# Altium schematic sheet Hopper.SchDoc(Hopper)
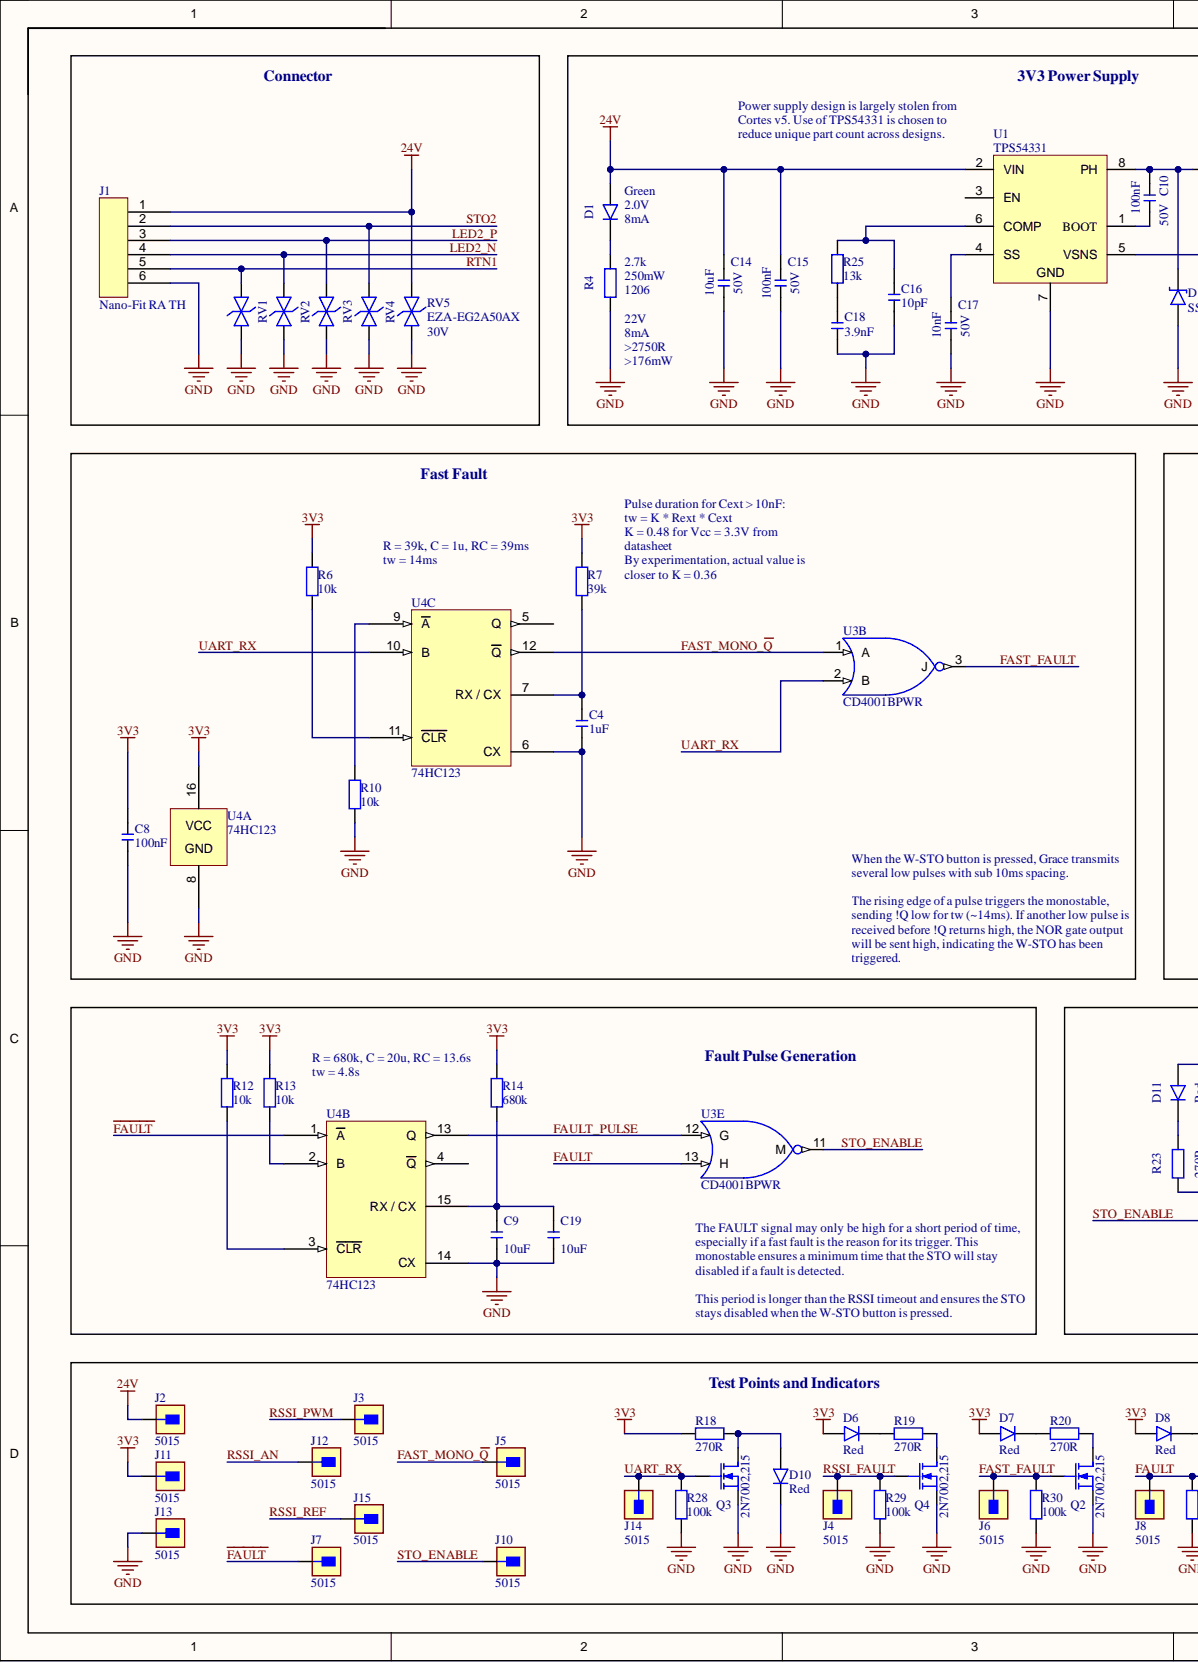
[diagram p0: Hopper.SchDoc, left]
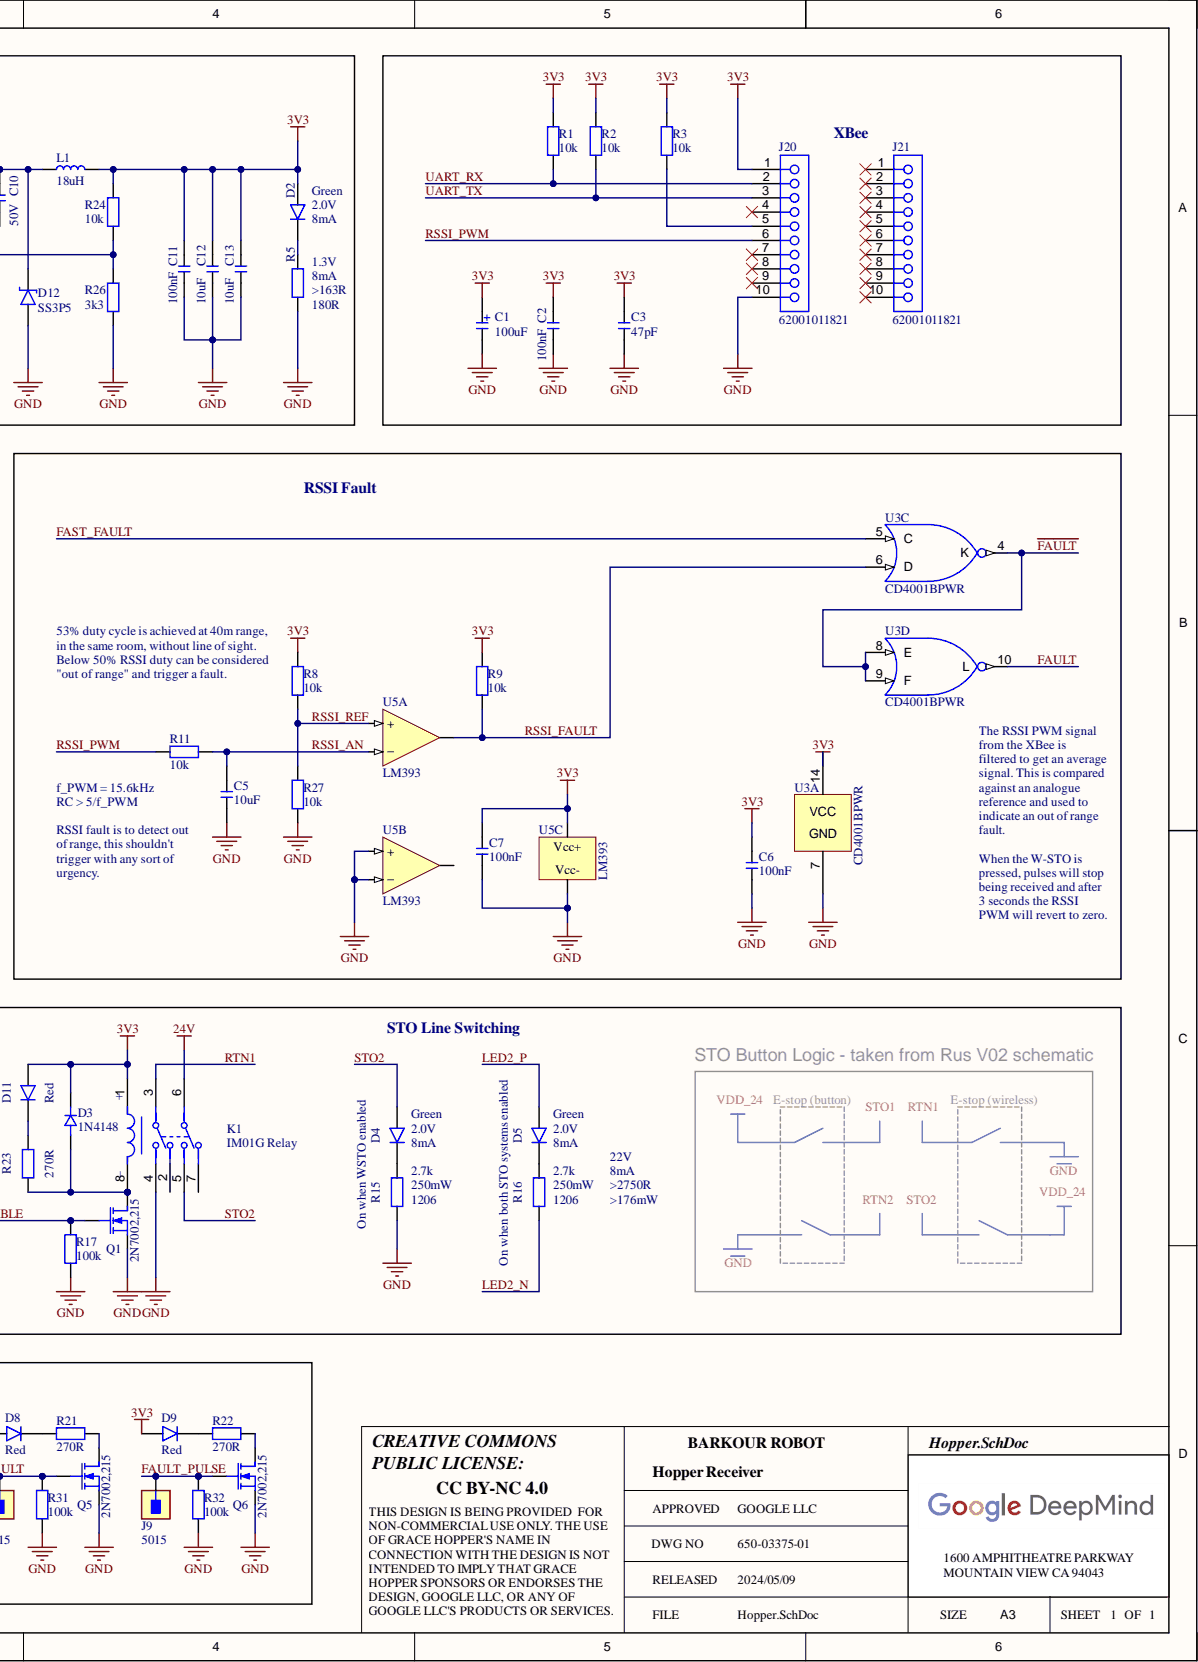
[diagram p1: Hopper.SchDoc, right]

## Parts
C1  100uF  [CAP ALSMD ELEC 5.3MM H5.8MM]
C2  100nF  [CAP 0402_1005 RF]
C3  47pF  [CAP 0603_1608 RF]
C4  1uF  [CAP 0603_1608 RF]
C5  10uF  [CAP 0603_1608 RF]
C6  100nF  [CAP 0603_1608 RF]
C7  100nF  [CAP 0603_1608 RF]
C8  100nF  [CAP 0603_1608 RF]
C9  10uF  [CAP 0402_1005]
C10  100nF  Voltage Rating: 50V  [CAP 0402_1005 RF]
C11  100nF  [CAP 0402_1005 RF]
C12  10uF  [CAP 0402_1005 RF]
C13  10uF  [CAP 0402_1005 RF]
C14  10uF  Voltage Rating: 50V  [CAP 1206_3216]
C15  100nF  Voltage Rating: 50V  [CAP 0402_1005 RF]
C16  10pF  [CAP 0402_1005 RF]
C17  10nF  Voltage Rating: 50V  [CAP 0402_1005 RF]
C18  3.9nF  [CAP 0402_1005 RF]
C19  10uF  [CAP 0402_1005]
D1  Colour: Green  Forward Voltage: 2.0V  [LED 0402_1005 GREEN]  (hidden comment: 150040VS73240)
D2  Colour: Green  Forward Voltage: 2.0V  [LED 0402_1005 GREEN]  (hidden comment: 150040VS73240)
D3  1N4148  [SOD323]
D4  Colour: Green  Forward Voltage: 2.0V  [LED 0402_1005 GREEN]  (hidden comment: 150040VS73240)
D5  Colour: Green  Forward Voltage: 2.0V  [LED 0402_1005 GREEN]  (hidden comment: 150040VS73240)
D6  Colour: Red  [LED 0402_1005 RED]  (hidden comment: 150040RS73240)
D7  Colour: Red  [LED 0402_1005 RED]  (hidden comment: 150040RS73240)
D8  Colour: Red  [LED 0402_1005 RED]  (hidden comment: 150040RS73240)
D9  Colour: Red  [LED 0402_1005 RED]  (hidden comment: 150040RS73240)
D10  Colour: Red  [LED 0402_1005 RED]  (hidden comment: 150040RS73240)
D11  Colour: Red  [LED 0402_1005 RED]  (hidden comment: 150040RS73240)
D12  Colloquial: SS3P5  [DO-220AA]  (hidden comment: SS3P5-M3/84A)
J1  Colloquial: Nano-Fit RA TH  [MOLEX 1053141206]  (hidden comment: 105314-1206)
J2  5015  [FP-5015-MFG-RF]
J3  5015  [FP-5015-MFG-RF]
J4  5015  [FP-5015-MFG-RF]
J5  5015  [FP-5015-MFG-RF]
J6  5015  [FP-5015-MFG-RF]
J7  5015  [FP-5015-MFG-RF]
J8  5015  [FP-5015-MFG-RF]
J9  5015  [FP-5015-MFG-RF]
J10  5015  [FP-5015-MFG-RF]
J11  5015  [FP-5015-MFG-RF]
J12  5015  [FP-5015-MFG-RF]
J13  5015  [FP-5015-MFG-RF]
J14  5015  [FP-5015-MFG-RF]
J15  5015  [FP-5015-MFG-RF]
J20  62001011821  [62001011821]
J21  62001011821  [62001011821]
K1  1462037-1  [TE IM Relay Gullwing]  (value not drawn)
L1  Inductance: 18uH  [INDUCTOR SMD SHIELDED 10x10mm]  (hidden comment: SRR1050A-180Y)
Q1  2N7002,215  [NEXPERIA SOT-23-3]
Q2  2N7002,215  [NEXPERIA SOT-23-3]
Q3  2N7002,215  [NEXPERIA SOT-23-3]
Q4  2N7002,215  [NEXPERIA SOT-23-3]
Q5  2N7002,215  [NEXPERIA SOT-23-3]
Q6  2N7002,215  [NEXPERIA SOT-23-3]
R1  10k  [RES 0402_1005 RF]
R2  10k  [RES 0402_1005 RF]
R3  10k  [RES 0402_1005 RF]
R4  2.7k  Power Rating: 250mW  Size Code: 1206  [RES 1206_3216 RF]
R5  180R  [RES 0402_1005 RF]
R6  10k  [RES 0402_1005 RF]
R7  39k  [RES 0603_1608 RF]
R8  10k  [RES 0402_1005 RF]
R9  10k  [RES 0402_1005 RF]
R10  10k  [RES 0402_1005 RF]
R11  10k  [RES 0402_1005 RF]
R12  10k  [RES 0402_1005 RF]
R13  10k  [RES 0402_1005 RF]
R14  680k  [RES 0603_1608 RF]
R15  2.7k  Power Rating: 250mW  Size Code: 1206  [RES 1206_3216 RF]
R16  2.7k  Power Rating: 250mW  Size Code: 1206  [RES 1206_3216 RF]
R17  100k  [RES 0402_1005 RF]
R18  270R  [RES 0402_1005 RF]
R19  270R  [RES 0402_1005 RF]
R20  270R  [RES 0402_1005 RF]
R21  270R  [RES 0402_1005 RF]
R22  270R  [RES 0402_1005 RF]
R23  270R  [RES 0402_1005 RF]
R24  10k  [RES 0402_1005 RF]
R25  13k  [RES 0402_1005 RF]
R26  3k3  [RES 0402_1005 RF]
R27  10k  [RES 0402_1005 RF]
R28  100k  [RES 0402_1005 RF]
R29  100k  [RES 0402_1005 RF]
R30  100k  [RES 0402_1005 RF]
R31  100k  [RES 0402_1005 RF]
R32  100k  [RES 0402_1005 RF]
RV1  EZA-EG2A50AX  [RES 0402_1005]
RV2  EZA-EG2A50AX  [RES 0402_1005]
RV3  EZA-EG2A50AX  [RES 0402_1005]
RV4  EZA-EG2A50AX  [RES 0402_1005]
RV5  EZA-EG2A50AX  Max Voltage Rating (DC): 30V  [RES 0402_1005]
U1  Colloquial: TPS54331  [TI SOIC-8 D0008A]  (hidden comment: TPS54331GDR)
U3  CD4001BPWR  [TI TSSOP-14 PW]  (parts A,B,C,D,E)
U4  CD74HC123PW  [TI TSSOP-16 PW]  (value not drawn)  (parts A,B,C)
U5  LM393PT  [ST TSSOP-8]  (value not drawn)  (parts A,B,C)

## Nets
3V3: C1.1 C2.2 C3.2 C6.2 C7.2 C8.2 C11.2 C12.2 C13.2 D2.1 D3.2 D6.1 D7.1 D8.1 D9.1 D11.1 J11.1 J20.1 K1.1 L1.2 R1.2 R2.2 R3.2 R6.2 R7.2 R8.2 R9.2 R12.2 R13.2 R14.2 R18.2 R24.2 U3.14 U4.16 U5.8
24V: C14.2 C15.2 D1.1 J1.1 J2.1 K1.6 RV5.2 U1.2(VIN)
GND: C1.2 C2.1 C3.1 C4.1 C5.1 C6.1 C7.1 C8.1 C9.1 C11.1 C12.1 C13.1 C14.1 C15.1 C16.2 C17.1 C18.1 C19.1 D10.2 D12.1 J1.6 J13.1 J20.10 K1.4 Q1.2 Q2.2 Q3.2 Q4.2 Q5.2 Q6.2 R4.1 R5.1 R10.1 R15.1 R17.1 R26.1 R27.1 R28.1 R29.1 R30.1 R31.1 R32.1 RV1.1 RV2.1 RV3.1 RV4.1 RV5.1 U1.7 U3.7 U4.6(CX) U4.8 U4.14(CX) U5.4 U5.5(+) U5.6(−)
~{FAULT}: J7.1 U3.4(K) U3.8(E) U3.9(F) U4.1(A)
FAST_FAULT: J6.1 Q2.1 R30.2 U3.3(J) U3.5(C)
FAST_MONO_~{Q}: J5.1 U3.1(A) U4.12(Q)
FAULT: J8.1 Q5.1 R31.2 U3.10(L) U3.13(H)
FAULT_PULSE: J9.1 Q6.1 R32.2 U3.12(G) U4.13(Q)
LED2_N: J1.4 R16.1 RV2.2
LED2_P: D5.1 J1.3 RV3.2
RSSI_AN: C5.2 J12.1 R11.2 U5.2(−)
RSSI_FAULT: J4.1 Q4.1 R9.1 R29.2 U3.6(D) U5.1
RSSI_PWM: J3.1 J20.6 R11.1
RSSI_REF: J15.1 R8.1 R27.2 U5.3(+)
RTN1: J1.5 K1.3 RV1.2
STO2: D4.1 J1.2 K1.5 RV4.2
STO_ENABLE: J10.1 Q1.1 R17.2 U3.11(M)
UART_RX: J14.1 J20.2 Q3.1 R1.1 R28.2 U3.2(B) U4.10(B)
UART_TX: J20.3 R2.1
NetC4_2: C4.2 R7.1 U4.7(RX_/_CX)
NetC9_2: C9.2 C19.2 R14.1 U4.15(RX_/_CX)
NetC10_1: C10.1 U1.1(BOOT)
NetC10_2: C10.2 D12.2 L1.1 U1.8(PH)
NetC16_1: C16.1 R25.2 U1.6(COMP)
NetC17_2: C17.2 U1.4(SS)
NetC18_2: C18.2 R25.1
NetD1_2: D1.2 R4.2
NetD2_2: D2.2 R5.2
NetD3_1: D3.1 K1.8 Q1.3 R23.1
NetD4_2: D4.2 R15.2
NetD5_2: D5.2 R16.2
NetD6_2: D6.2 R19.2
NetD7_2: D7.2 R20.2
NetD8_2: D8.2 R21.2
NetD9_2: D9.2 R22.2
NetD10_1: D10.1 Q3.3 R18.1
NetD11_2: D11.2 R23.2
NetJ20_5: J20.5 R3.1
NetQ2_3: Q2.3 R20.1
NetQ4_3: Q4.3 R19.1
NetQ5_3: Q5.3 R21.1
NetQ6_3: Q6.3 R22.1
NetR6_1: R6.1 U4.11(CLR)
NetR10_2: R10.2 U4.9(A)
NetR12_1: R12.1 U4.3(CLR)
NetR13_1: R13.1 U4.2(B)
NetR24_1: R24.1 R26.2 U1.5(VSNS)

Not connected: J20 4 7 8 9; J21 1 2 3 4 5 6 7 8 9 10; K1 2 7; U1 3(EN); U4 4(Q) 5(Q); U5 7

## Library data of the parts
C1 = C_POL: Generic Polarised Capacitor; Tolerance: 20%; Voltage Rating: 16V; Capacitance: 100uF; Component Kind: Standard; Diameter: 5mm; Height: 5.8mm; Size Code: D5.0 x H5.8; Library Name: DMR_common_lib.SchLib
C2 C11 C18 = C: Generic Capacitor; Temperature Coefficient: X7R; Tolerance: 10%; Voltage Rating: 50V; Component Kind: Standard; Size Code: 0402; Library Name: DMR_common_lib.SchLib
  C2 C11: Capacitance: 100nF
  C18: Capacitance: 3.9nF
C3 = C: Capacitor; Dielectric: C0G; Tolerance: 20%; Voltage Rating: 50V; Capacitance: 47pF; Component Kind: Standard; Size Code: 0603; Library Name: DMR_common_lib.SchLib
C4 = C: Capacitor; Dielectric: X7R; Tolerance: 20%; Voltage Rating: 10V; Capacitance: 1uF; Component Kind: Standard; Size Code: 0603; Library Name: DMR_common_lib.SchLib
C5 = C: Capacitor; Dielectric: X5R; Tolerance: 10%; Voltage Rating: 10V; Capacitance: 10uF; Component Kind: Standard; Size Code: 0603; Library Name: DMR_common_lib.SchLib
C6-C8 = C: Capacitor; Dielectric: X7R; Tolerance: 20%; Voltage Rating: 25V; Capacitance: 100nF; Component Kind: Standard; Size Code: 0603; Library Name: DMR_common_lib.SchLib
C9 C12 C13 C19 = C: Generic Capacitor; Temperature Coefficient: X5R; Tolerance: 20%; Voltage Rating: 6.3V; Capacitance: 10uF; Component Kind: Standard; Size Code: 0402; Library Name: DMR_common_lib.SchLib
C10 C15 C17 = C: Generic Capacitor; Temperature Coefficient: X7R; Tolerance: 10%; Component Kind: Standard; Size Code: 0402; Library Name: DMR_common_lib.SchLib
  C10 C15: Capacitance: 100nF
  C17: Capacitance: 10nF
C14 = C: Generic Capacitor; Temperature Coefficient: X5R; Tolerance: 10%; Capacitance: 10uF; Component Kind: Standard; Size Code: 1206; Library Name: DMR_common_lib.SchLib
C16 = C: Generic Capacitor; Temperature Coefficient: C0G; Tolerance: 5%; Voltage Rating: 50V; Capacitance: 10pF; Component Kind: Standard; Size Code: 0402; Library Name: DMR_common_lib.SchLib
D1 D2 D4 D5 = LED_GREEN_0402: Green SMD LED, 0402, 20mA Ic, 2V; Luminous Intensity: 50mcd; Wavelength: 570nm; Case Size: 0402; Component Kind: Standard; Forward Current: 20mA; Manufacturer Part Number: 150040VS73240; Library Name: DMR_common_lib.SchLib
D3 = 1N4148: Signal Diode VR=75V IF=150mA Trr=4ns P=200mW; Manufacturer: Diodes; Manufacturer Part Number: 1N4148WS-7-F; Average Rectified Current: 150mA; Capacitance: 2pF; Element Configuration: Single; Forward Current: 300mA; Forward Voltage: 1.25V; Max Forward Surge Current (Ifsm): 2A; Max Repetitive Reverse Voltage (Vrrm): 75V; Max Reverse Current: 1uA; Polarity: Standard; Power Dissipation: 200mW; Recovery Time: 4ns; Category: Rectifier Diodes; Colloquial: 1N4148; Component Kind: Standard; Contact Plating: Tin,Matte; Current Rating: 150mA; Height: 1mm; Lead Free: Lead Free; Length: 1.8mm; Max Operating Temperature: 150°C; Max Reverse Voltage (DC): 75V; Max Surge Current: 2A; Min Operating Temperature: -65°C; Mount: Surface Mount; Number of Pins: 2; Output Current: 150mA; Package Quantity: 3000; Packaging: Tape and Reel; Peak Non-Repetitive Surge Current: 2A; Power Rating: 200mW; Pricing 1: 3000=0.03600, 6000=0.03200, 12000=0.02900, 30000=0.02700, 60000=0.02300 (USD); Radiation Hardening: No; REACH SVHC: No SVHC; Reverse Recovery Time: 4ns; Reverse Recovery Time-Max: 4ns; RoHS: Yes; RoHS Compliant: Yes; Series: -; Stock 1: 18000; Supplier 1: RSComponents; Supplier Part Number 1: 9228070; Voltage: 75V; Voltage Rating (DC): 75V; Weight: 0.000173oz; Width: 1.4mm; Library Name: DMR_common_lib.SchLib
D6-D11 = LED_RED_0402: Red SMD LED, 0402, 20mA Ic, 2V; Forward Voltage: 2.0V; Luminous Intensity: 100mcd; Wavelength: 625nm; Case Size: 0402; Component Kind: Standard; Forward Current: 20mA; Manufacturer Part Number: 150040RS73240; Library Name: DMR_common_lib.SchLib
D12 = SS3P5-M3/84A: VISHAY   SS3P5-M3/84A   DIODE, RECTIFIER, SCHOTTKY, 3A, 50V, SMP; Element Configuration: Single; Forward Voltage: 780mV; Max Forward Surge Current (Ifsm): 45A; Max Reverse Current: 100uA; Average Rectified Current: 3A; Case/Package: DO-220AA; Category: Rectifier Diodes; Component Kind: Standard; ComponentLink1Description: https://datasheet.ciiva.com/29591/ss3p5-29591977.pdf?src-supplier=Digi-Key; ComponentLink1URL: https://datasheet.ciiva.com/29591/ss3p5-29591977.pdf?src-supplier=Digi-Key; ComponentLink2Description: https://datasheet.ciiva.com/8289/ss3p5-8289162.pdf?src-supplier=Avnet; ComponentLink2URL: https://datasheet.ciiva.com/8289/ss3p5-8289162.pdf?src-supplier=Avnet; ComponentLink3Description: https://datasheet.ciiva.com/7962/ss3p5-7962430.pdf?src-supplier=Element14; ComponentLink3URL: https://datasheet.ciiva.com/7962/ss3p5-7962430.pdf?src-supplier=Element14; Forward Current: 3A; Height: 1.15mm; Length: 3.61mm; Manufacturer: Vishay; Manufacturer Part Number: SS3P5-M3/84A; Max Operating Temperature: 150°C; Max Repetitive Reverse Voltage (Vrrm): 50V; Max Reverse Voltage (DC): 50V; Min Operating Temperature: -55°C; Mount: Surface Mount; Number of Pins: 2; Package Quantity: 3000; Packaging: Tape and Reel; Peak Non-Repetitive Surge Current: 45A; Pricing 1: 10=0.45800, 50=0.45100 (USD); Radiation Hardening: No; REACH SVHC: Unknown; RoHS: Yes; RoHS Compliant: Yes; Series: eSMP®; Stock 1: 1; Supplier 1: RSComponents; Supplier Part Number 1: 7103752P; Weight: 0.000847oz; Width: 2.18mm; Library Name: DMR_common_lib.SchLib
J1 = Nano-Fit RA TH 6 Way Header Dual Row: Nano-Fit Right Angle Header; Through Hole; 2.50mm; Dual Row; 6 Circuit; Gold Plated; Manufacturer: Molex; Gender: Male; Category: Headers and Wire Housings; Color: Black; Component Kind: Standard; Contact Material: Brass; Contact Plating: Gold; Manufacturer Part Number: 105314-1206; Mount: Through Hole; Number of Rows: 2; Pricing 1: 10=1.38000 (GBP); REACH SVHC: Unknown; RoHS: Yes; RoHS Compliant: Yes; Stock 1: 621; Supplier 1: Farnell; Supplier Part Number 1: 2576871; Termination: Through Hole; Library Name: DMR_common_lib.SchLib
J2-J15 = 5015: PC TEST POINT MINIATURE; Manufacturer: keystone; Conn Orientation: Straight; Number Of Contacts: 1; Automotive: No; Category: Conn; Component Kind: Standard; ComponentLink2Description: Datasheet; ComponentLink2URL: http://www.keyelco.com/product-pdf.cfm?p=1353; Contact Material: Silver; Device Class L1: Connectors; Device Class L2: unset; Device Class L3: unset; Digikey Description: PC TEST POINT MINIATURE; Height: 1.65mm; Lead Free: Yes; Manufacturer Part Number: 5015; Octopart: https://octopart.com/5015-keystone-3822; Package: 5015; RoHS: TRUE; Library Name: DMR_common_lib.SchLib
J20 J21 = CMP-1502-01210-2: NexarPartId: 65162168; Contact Resistance: 20mΩ; Current Rating: 2A; Gender: Female; Operating Supply Voltage: 250V; Component Kind: Standard; Lead Pitch: 2mm; Library Name: Altium Content Vault; Number of Pins: 10; Orientation: Vertical; RoHS: Non-Compliant
K1 = IM01G: Signal Relay 3VDC 2A DPDT (( 10mm 7.5mm 5.65mm)) Surface Mount Automotive; Manufacturer: TE Connectivity Axicom; Colloquial: IM01G Relay; Category: Signal Relays; Component Kind: Standard; Manufacturer Part Number: 1462037-1; Pricing 1: 1=2.25000, 100=1.60000, 250=1.45000, 500=1.27000 (GBP); RoHS: Yes; Stock 1: 745; Library Name: DMR_common_lib.SchLib
L1 = SRR1050A-180Y: Inductor Power Shielded Wirewound 18uH 30% 100KHz Ferrite 3.1A 0.051Ohm DCR Automotive T/R; Manufacturer: Bourns; DC Resistance (DCR): 51mR; Saturation Current: 3.2A; Category: Fixed Inductors; Component Kind: Standard; ComponentLink1Description: https://www.bourns.com/docs/Product-Datasheets/SRR1050A.pdf?src-supplier=Digi-Key; ComponentLink1URL: https://www.bourns.com/docs/Product-Datasheets/SRR1050A.pdf?src-supplier=Digi-Key; ComponentLink2Description: http://www.farnell.com/datasheets/2113225.pdf?src-supplier=Element14; ComponentLink2URL: http://www.farnell.com/datasheets/2113225.pdf?src-supplier=Element14; Inductance Tolerance: 30%; Manufacturer Part Number: SRR1050A-180Y; Mount: Surface Mount; Packaging: Cut Tape; Pricing 1: 1=1.29000, 10=0.94500, 50=0.94500, 100=0.79400, 1000=0.68100, 10000=0.54800 (USD); REACH SVHC: No SVHC; RMS Current (Irms): 3.1A; RoHS: Yes; RoHS Compliant: Yes; Stock 1: 5557; Supplier 1: Mouser; Supplier Part Number 1: 652-SRR1050A-180Y; Library Name: DMR_common_lib.SchLib
Q1-Q6 = 2N7002: MOSFET N-CH 60V 300MA SOT-23; Manufacturer: Nexperia; Category: MOSFETs; Component Kind: Standard; ComponentLink1Description: http://www.farnell.com/datasheets/1499179.pdf?src-supplier=Farnell; ComponentLink1URL: http://www.farnell.com/datasheets/1499179.pdf?src-supplier=Farnell; ComponentLink2Description: https://assets.nexperia.com/documents/data-sheet/BSS138PS.pdf?src-supplier=Digi-Key; ComponentLink2URL: https://assets.nexperia.com/documents/data-sheet/BSS138PS.pdf?src-supplier=Digi-Key; ComponentLink3Description: https://assets.nexperia.com/documents/data-sheet/2N7002.pdf?src-supplier=Digi-Key; ComponentLink3URL: https://assets.nexperia.com/documents/data-sheet/2N7002.pdf?src-supplier=Digi-Key; ComponentLink4Description: http://www.farnell.com/datasheets/1499179.pdf?src-supplier=Newark; ComponentLink4URL: http://www.farnell.com/datasheets/1499179.pdf?src-supplier=Newark; Manufacturer Part Number: 2N7002,215; Pricing 1: 3000=0.05700, 9000=0.05640, 24000=0.05590, 45000=0.05530 (USD); RoHS: Yes; RoHS Compliant: Yes; Stock 1: 243000; Supplier 1: Arrow Electronics; Supplier Part Number 1: 2N7002,215; Library Name: DMR_common_lib.SchLib
R1-R3 R5 R6 R8-R13 R17-R32 = R: Generic Resistor; Power Rating: 62.5mW; Temperature Coefficient: 100ppm/°C; Tolerance: 1%; Voltage Rating: 50V; Component Kind: Standard; Size Code: 0402; Library Name: DMR_common_lib.SchLib
  R1-R3 R6 R8-R13 R24 R27: Resistance: 10k
  R5: Resistance: 180R
  R17 R28-R32: Resistance: 100k
  R18-R23: Resistance: 270R
  R25: Resistance: 13k
  R26: Resistance: 3k3
R4 R15 R16 = R: Resistor; Temperature Coefficient: 100ppm/°C; Tolerance: 1%; Voltage Rating: 200V; Component Kind: Standard; Resistance: 2.7k; Library Name: DMR_common_lib.SchLib
R7 R14 = R: Resistor; Power Rating: 100mW; Temperature Coefficient: 100ppm/°C; Tolerance: 1%; Voltage Rating: 75V; Component Kind: Standard; Size Code: 0603; Library Name: DMR_common_lib.SchLib
  R7: Resistance: 39k
  R14: Resistance: 680k
RV1-RV4 = EZA-EG2A50AX: EZAEG Series 100 Vcl 0.05 pF 0402 Surface Mount ESD Suppressor; Manufacturer: Panasonic; Breakdown Voltage: 15V; Capacitance: 0.1pF; Clamping Voltage: 100V; Current Rating: 2A; Direction: Bidirectional; Element Configuration: Single; ESD Protection: Yes; Max Voltage Rating (DC): 30V; Number of Channels: 1; Working Voltage: 500V; Case Code (Imperial): 0402; Case Code (Metric): 1005; Case/Package: 0402; Category: Varistors; Component Kind: Standard; Depth: 0.5mm; Height: 0.015inch; Lead Free: Lead Free; Length: 1mm; Manufacturer Part Number: EZA-EG2A50AX; Max Operating Temperature: 125°C; Min Operating Temperature: -55°C; Mount: Surface Mount; Number of Circuits: 1; Number of Pins: 2; Package Quantity: 1; Packaging: Tape and Reel; Polarity: Bidirectional; Pricing 1: 1=0.35820, 10=0.30530, 25=0.26250, 100=0.22160, 250=0.18290, 500=0.15520, 1000=0.12540, 3000=0.11050 (USD); Radiation Hardening: No; REACH SVHC: Unknown; RoHS: Yes; RoHS Compliant: Yes; Series: -; Stock 1: 5580; Supplier 1: Arrow Electronics; Supplier Part Number 1: EZA-EG2A50AX; Termination: SMD/SMT; Test Current: 2A; Voltage Rating (DC): 15V; Width: 0.02inch; Library Name: DMR_common_lib.SchLib
RV5 = EZA-EG2A50AX: EZAEG Series 100 Vcl 0.05 pF 0402 Surface Mount ESD Suppressor; Manufacturer: Panasonic; Breakdown Voltage: 15V; Capacitance: 0.1pF; Clamping Voltage: 100V; Current Rating: 2A; Direction: Bidirectional; Element Configuration: Single; ESD Protection: Yes; Number of Channels: 1; Working Voltage: 500V; Case Code (Imperial): 0402; Case Code (Metric): 1005; Case/Package: 0402; Category: Varistors; Component Kind: Standard; Depth: 0.5mm; Height: 0.015inch; Lead Free: Lead Free; Length: 1mm; Manufacturer Part Number: EZA-EG2A50AX; Max Operating Temperature: 125°C; Min Operating Temperature: -55°C; Mount: Surface Mount; Number of Circuits: 1; Number of Pins: 2; Operating Supply Voltage: 30V; Package Quantity: 1; Packaging: Tape and Reel; Polarity: Bidirectional; Pricing 1: 1=0.35820, 10=0.30530, 25=0.26250, 100=0.22160, 250=0.18290, 500=0.15520, 1000=0.12540, 3000=0.11050 (USD); Radiation Hardening: No; REACH SVHC: Unknown; RoHS: Yes; RoHS Compliant: Yes; Series: -; Stock 1: 5580; Supplier 1: Arrow Electronics; Supplier Part Number 1: EZA-EG2A50AX; Termination: SMD/SMT; Test Current: 2A; Voltage Rating (DC): 15V; Width: 0.02inch; Library Name: DMR_common_lib.SchLib
U1 = TPS54331GDR: 3.5V to 28V Input, 3A, 570kHz Step-Down Converter with Eco-mode 8-SOIC -40 to 150; Manufacturer: Texas Instruments; Max Output Voltage: 25V; Min Output Voltage: 800mV; Number of Outputs: 1; Output Type: Adjustable; Quiescent Current: 110uA; Synchronous Rectifier: No; Case/Package: D; Category: Voltage Regulators - Switching; Component Kind: Standard; ComponentLink1Description: https://datasheet.ciiva.com/14378/tps54331-14378086.pdf?src-supplier=Avnet; ComponentLink1URL: https://datasheet.ciiva.com/14378/tps54331-14378086.pdf?src-supplier=Avnet; ComponentLink2Description: https://datasheet.ciiva.com/2034/tps54331-2034843.pdf?src-supplier=Digi-Key; ComponentLink2URL: https://datasheet.ciiva.com/2034/tps54331-2034843.pdf?src-supplier=Digi-Key; Lead Free: Lead Free; Manufacturer Part Number: TPS54331GDR; Max Input Voltage: 28V; Max Operating Temperature: 150°C; Max Output Current: 3A; Min Input Voltage: 3.5V; Min Operating Temperature: -40°C; Number of Pins: 8; Operating Supply Voltage: 28V; Output Current: 3A; Output Voltage: 25V; Package Quantity: 2500; Packaging: Tape and Reel; Pricing 1: 1=1.85000, 10=1.67000, 25=1.57000, 50=1.57000, 100=1.34000, 250=1.26000, 500=1.10000, 1000=0.91100, 2500=0.91000, 10000=0.91000 (USD); RoHS: Yes; RoHS Compliant: Yes; Series: SWIFT™, Eco-Mode™; Supplier 1: Mouser; Supplier Part Number 1: 595-TPS54331GDR; Switching Frequency: 570kHz; Library Name: DMR_common_lib.SchLib
U3 = CD4001BPWR: 4-ch, 2-input, 3-V to 18-V NOR gates 14-TSSOP -55 to 125; Manufacturer: Texas Instruments; Colloquial: 4001; High Level Output Current: -4.2mA; Low Level Output Current: 4.2mA; Max Supply Voltage: 18V; Min Supply Voltage: 3V; Number of Bits: 4; Number of Input Lines: 2; Number of Output Lines: 1; Output Current: 6.8mA; Propagation Delay: 90ns; Quiescent Current: 5uA; Case/Package: TSSOP; Category: Logic Gates; Component Kind: Standard; Contact Plating: Gold; Lead Free: Lead Free; Logic Function: NOR GATE; Manufacturer Part Number: CD4001BPWR; Max Operating Temperature: 125°C; Min Operating Temperature: -55°C; Mount: Surface Mount; Number of Circuits: 4; Number of Elements: 4; Number of Gates: 4; Number of Inputs: 2; Number of Outputs: 1; Number of Pins: 14; Package Quantity: 1; Packaging: Tape and Reel; Pricing 1: 1=0.59000, 10=0.50200, 50=0.50200, 100=0.34800, 1000=0.22800, 10000=0.20700 (USD); Radiation Hardening: No; REACH SVHC: No SVHC; RoHS: Yes; RoHS Compliant: Yes; Series: 4000B; Supplier 1: Mouser; Supplier 2: Digi-Key; Supplier 3: Digi-Key; Supplier Part Number 1: 595-CD4001BPWR; Supplier Part Number 2: 296-32851-5-ND; Supplier Part Number 3: NLV14001BDTR2G-ND; Technology: CMOS; Turn-On Delay Time: 90ns; Weight: 0.002018oz; Library Name: DMR_common_lib.SchLib
U4 = 74HC123: High Speed CMOS Logic Dual etriggerable Monostable Multivibrators with eset 16-TSSOP -55 to 125; High Level Output Current: -5.2mA; Independent Circuits: 2; Low Level Output Current: 5.2mA; Max Supply Voltage: 6V; Min Supply Voltage: 2V; Operating Supply Voltage: 5V; Propagation Delay: 25ns; Quiescent Current: 8uA; Schmitt Trigger Input: Yes; Turn-On Delay Time: 54ns; Case/Package: TSSOP; Category: Timers and Oscillators; Colloquial: 74HC123; Component Kind: Standard; Height: 1.15mm; Lead Free: Lead Free; Length: 5mm; Logic Function: Multivibrator; Manufacturer Part Number: CD74HC123PW; Max Operating Temperature: 125°C; Min Operating Temperature: -55°C; Mount: Surface Mount; Number of Bits: 2; Number of Elements: 2; Number of Pins: 16; Output Current: 5.2mA; Package Quantity: 90; Packaging: Rail/Tube; Pricing 1: 1=1.19000, 10=1.08000, 50=1.02000, 100=0.83600, 1000=0.69000, 10000=0.52700 (USD); Radiation Hardening: No; REACH SVHC: No SVHC; RoHS: Yes; Stock 1: 1774; Supplier 1: Mouser; Supplier 2: Mouser; Supplier Part Number 1: 595-CD74HC123PW; Supplier Part Number 2: 771-74HC123PW-T; Weight: 0.002183oz; Width: 4.4mm; Library Name: DMR_common_lib.SchLib
U5 = LM393: Comparator Dual 18V/36V 8-Pin TSSOP T/R; Manufacturer: ST; Input Bias Current: 250nA; Input Offset Voltage (Vos): 5mV; Max Dual Supply Voltage: 18V; Max Power Dissipation: 625mW; Max Supply Current: 1mA; Max Supply Voltage: 36V; Min Dual Supply Voltage: 1V; Min Supply Voltage: 2V; Natural Thermal Resistance: 120°C/W; Number of Channels: 2; Output Current: 18mA; Output Current per Channel: 100pA; Output Type: TTL; Quiescent Current: 2.5mA; Response Time: 1.3us; Voltage Gain: 106.02dB; Case/Package: TSSOP; Category: Comparators; Colloquial: LM393; Component Kind: Standard; ComponentLink1Description: https://datasheet.ciiva.com/15131/getdatasheetpartid-186418-15131021.pdf?src-supplier=Verical; ComponentLink1URL: https://datasheet.ciiva.com/15131/getdatasheetpartid-186418-15131021.pdf?src-supplier=Verical; ComponentLink2Description: https://datasheet.ciiva.com/1827/cd00000465-1827201.pdf?src-supplier=Digi-Key; ComponentLink2URL: https://datasheet.ciiva.com/1827/cd00000465-1827201.pdf?src-supplier=Digi-Key; ComponentLink3Description: https://datasheet.ciiva.com/1827/cd00000465-1827202.pdf?src-supplier=Digi-Key; ComponentLink3URL: https://datasheet.ciiva.com/1827/cd00000465-1827202.pdf?src-supplier=Digi-Key; ComponentLink4Description: https://datasheet.ciiva.com/1827/cd00000465-1827203.pdf?src-supplier=Digi-Key; ComponentLink4URL: https://datasheet.ciiva.com/1827/cd00000465-1827203.pdf?src-supplier=Digi-Key; ComponentLink5Description: https://datasheet.ciiva.com/2971/cd00000465-2971959.pdf?src-supplier=Avnet; ComponentLink5URL: https://datasheet.ciiva.com/2971/cd00000465-2971959.pdf?src-supplier=Avnet; ComponentLink6Description: https://datasheet.ciiva.com/16381/cd00000465-16381559.pdf?src-supplier=Future+Electronics; ComponentLink6URL: https://datasheet.ciiva.com/16381/cd00000465-16381559.pdf?src-supplier=Future+Electronics; Lead Free: Lead Free; Manufacturer Part Number: LM393PT; Max Input Current: 250nA; Max Operating Temperature: 70°C; Min Operating Temperature: 0°C; Mount: Surface Mount; Nominal Supply Current: 1mA; Number of Elements: 2; Number of Pins: 8; Package Quantity: 1; Packaging: Tape and Reel; Power Dissipation: 625mW; Pricing 1: 1=0.55700, 5=0.55700, 10=0.47600, 25=0.44300, 50=0.37500, 100=0.30600, 250=0.25300, 500=0.20100, 1000=0.16500 (USD); Radiation Hardening: No; RoHS: Yes; RoHS Compliant: Yes; Series: LM393; Stock 1: 4000; Supplier 1: Newark; Supplier Part Number 1: 69AH0634; Supply Current: 1mA; Technology: BIPOLAR; Type: General Purpose; Library Name: DMR_common_lib.SchLib
